# Altium schematic sheet Front_Panel.SchDoc
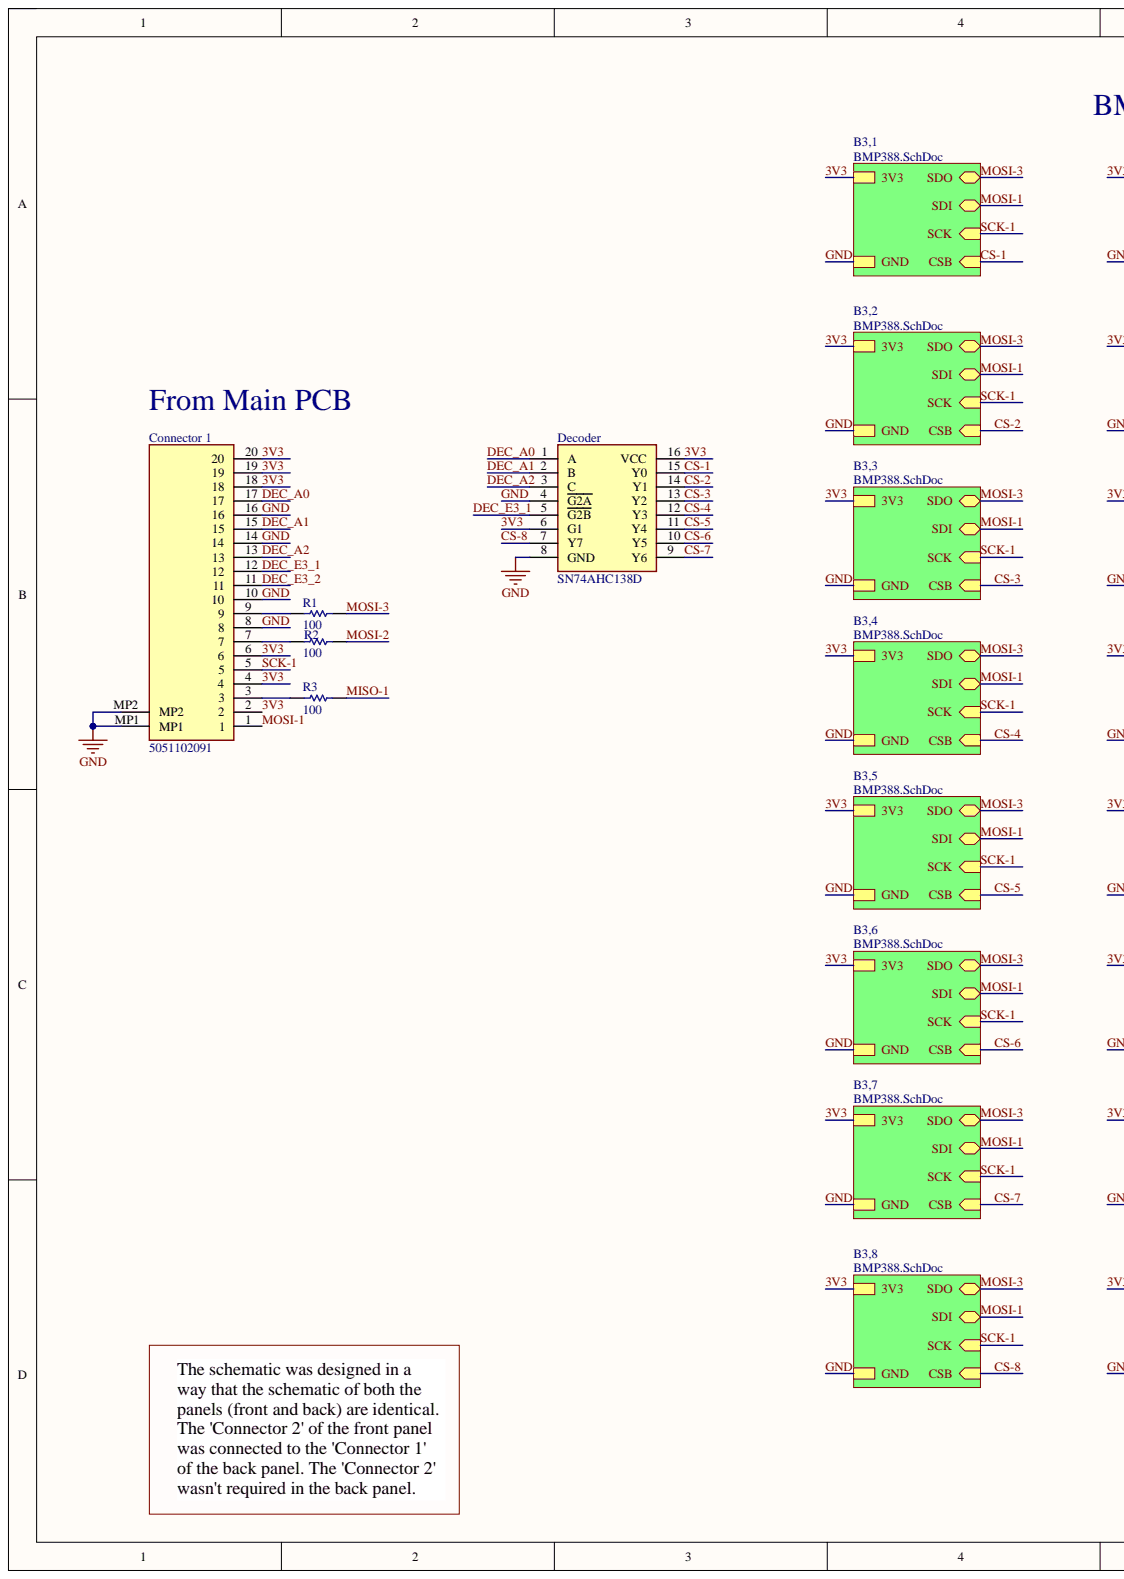
[diagram p0: Front_Panel.SchDoc, left]
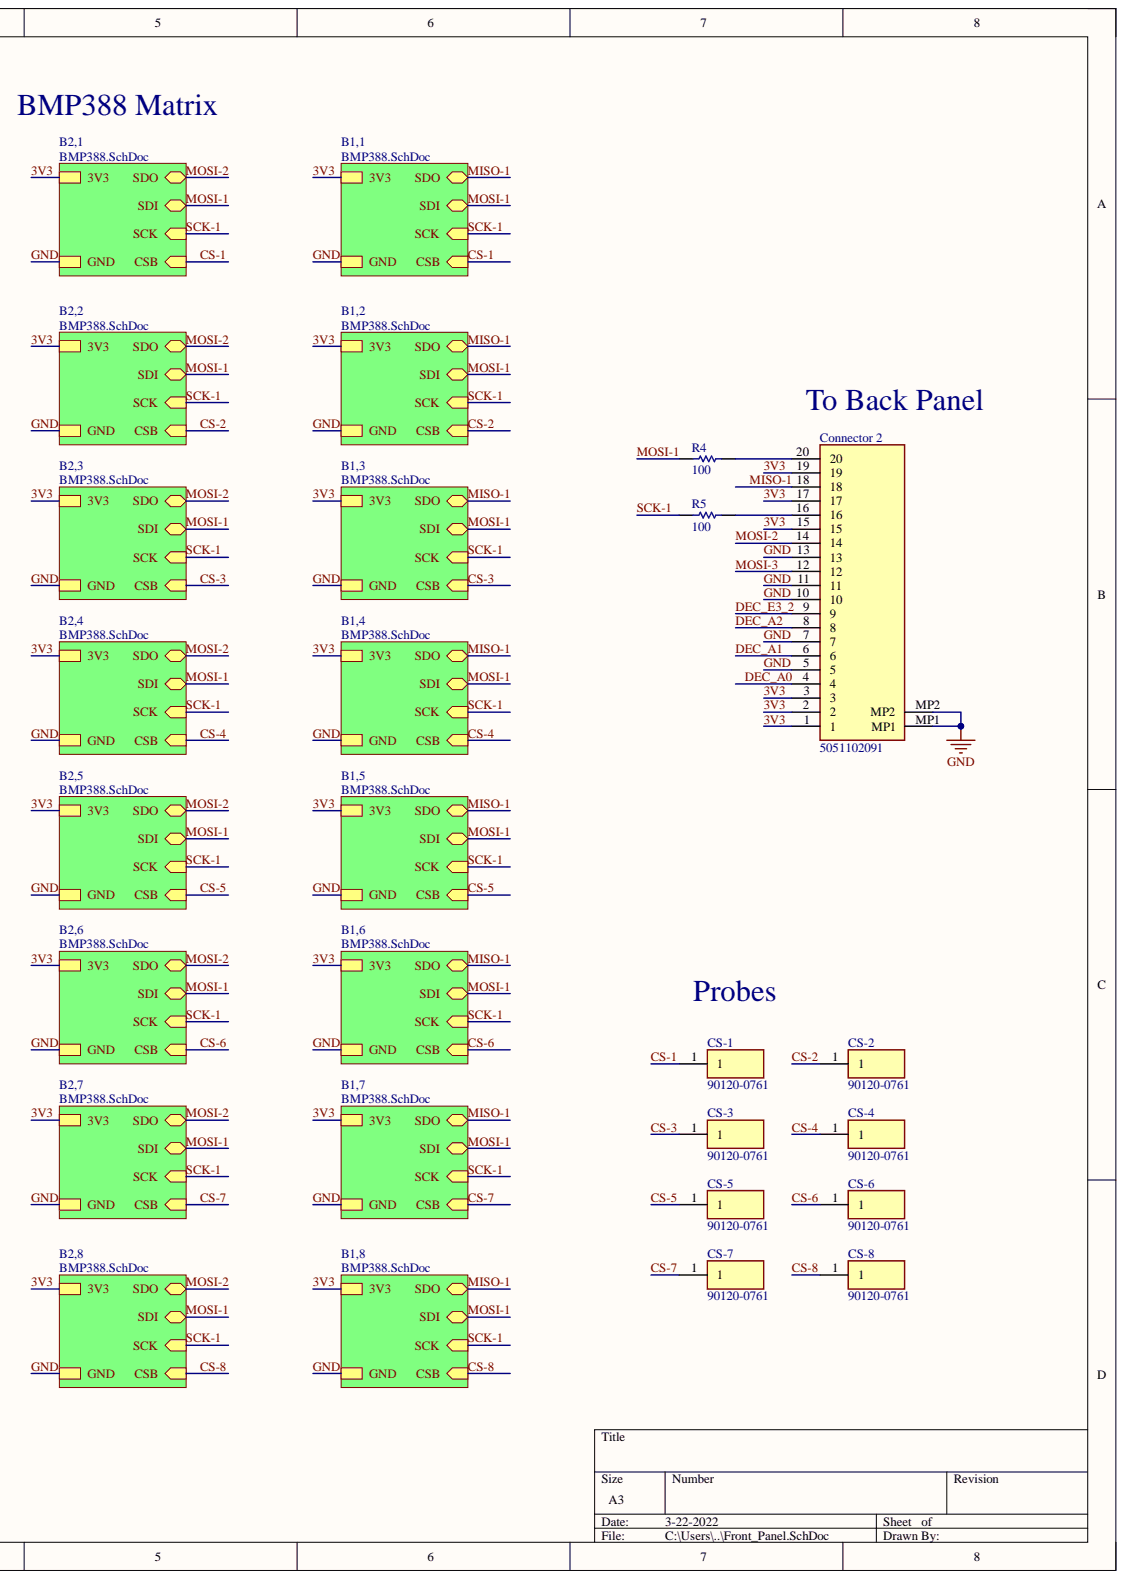
[diagram p1: Front_Panel.SchDoc, right]

## Parts
Connector_1  5051102091  [5051102091]
Connector_2  5051102091  [5051102091]
CS-1  90120-0761  [HDRV1W90P0X254_1X1_232X249X560P]
CS-2  90120-0761  [HDRV1W90P0X254_1X1_232X249X560P]
CS-3  90120-0761  [HDRV1W90P0X254_1X1_232X249X560P]
CS-4  90120-0761  [HDRV1W90P0X254_1X1_232X249X560P]
CS-5  90120-0761  [HDRV1W90P0X254_1X1_232X249X560P]
CS-6  90120-0761  [HDRV1W90P0X254_1X1_232X249X560P]
CS-7  90120-0761  [HDRV1W90P0X254_1X1_232X249X560P]
CS-8  90120-0761  [HDRV1W90P0X254_1X1_232X249X560P]
Decoder  SN74AHC138D  [SOIC127P600X175-16N]
R1  value 100  [J1-0603]  (hidden comment: Res1)
R2  value 100  [J1-0603]  (hidden comment: Res1)
R3  value 100  [J1-0603]  (hidden comment: Res1)
R4  value 100  [J1-0603]  (hidden comment: Res1)
R5  value 100  [J1-0603]  (hidden comment: Res1)

## Nets
3V3: Connector_1.2 Connector_1.4 Connector_1.6 Connector_1.18 Connector_1.19 Connector_1.20 Connector_2.1 Connector_2.2 Connector_2.3 Connector_2.15 Connector_2.17 Connector_2.19 Decoder.6(G1) Decoder.16(VCC)
CS-1: CS-1.1 Decoder.15(Y0)
CS-2: CS-2.1 Decoder.14(Y1)
CS-3: CS-3.1 Decoder.13(Y2)
CS-4: CS-4.1 Decoder.12(Y3)
CS-5: CS-5.1 Decoder.11(Y4)
CS-6: CS-6.1 Decoder.10(Y5)
CS-7: CS-7.1 Decoder.9(Y6)
CS-8: CS-8.1 Decoder.7(Y7)
DEC_A0: Connector_1.17 Connector_2.4 Decoder.1(A)
DEC_A1: Connector_1.15 Connector_2.6 Decoder.2(B)
DEC_A2: Connector_1.13 Connector_2.8 Decoder.3(C)
DEC_E3_1: Connector_1.12 Decoder.5(G2B)
DEC_E3_2: Connector_1.11 Connector_2.9
GND: Connector_1.8 Connector_1.10 Connector_1.14 Connector_1.16 Connector_1.MP1 Connector_1.MP2 Connector_2.5 Connector_2.7 Connector_2.10 Connector_2.11 Connector_2.13 Connector_2.MP1 Connector_2.MP2 Decoder.4(G2A) Decoder.8(GND)
MISO-1: Connector_2.18 R3.1
MOSI-1: Connector_1.1 R4.1
MOSI-2: Connector_2.14 R2.1
MOSI-3: Connector_2.12 R1.1
SCK-1: Connector_1.5 R5.1
NetConnector 1_3: Connector_1.3 R3.2
NetConnector 1_7: Connector_1.7 R2.2
NetConnector 1_9: Connector_1.9 R1.2
NetConnector 2_16: Connector_2.16 R5.2
NetConnector 2_20: Connector_2.20 R4.2

## Library data of the parts
Connector_1 Connector_2 = 5051102091: Connector; Manufacturer_Name: Molex; Arrow Part Number: 5051102091; Arrow Price/Stock: https://www.arrow.com/en/products/505110-2091/molex; Component Kind: Standard; Datasheet Link: https://www.molex.com/pdm_docs/sd/5051102091_sd.pdf; Height: 2.1mm; Library Name: SamacSys.SchLib; Manufacturer_Part_Number: 5051102091
CS-1-CS-8 = 90120-0761: Connector; Manufacturer_Name: Molex; Component Kind: Standard; Datasheet Link: https://www.molex.com/pdm_docs/sd/901200761_sd.pdf; Height: 5.6mm; Library Name: Test Point.SchLib; Manufacturer_Part_Number: 90120-0761; Mouser Part Number: 538-90120-0761; Mouser Price/Stock: https://www.mouser.co.uk/ProductDetail/Molex/90120-0761?qs=PvP5v7Eimsn%2F58TpDaAAow%3D%3D
Decoder = SN74AHC138D: Integrated Circuit; Manufacturer_Name: Texas Instruments; Arrow Part Number: SN74AHC138D; Arrow Price/Stock: https://www.arrow.com/en/products/sn74ahc138d/texas-instruments; Component Kind: Standard; Datasheet Link: http://www.ti.com/lit/ds/symlink/sn74ahc138.pdf; Height: 1.75mm; Manufacturer_Part_Number: SN74AHC138D; Mouser Part Number: 595-SN74AHC138D; Mouser Price/Stock: https://www.mouser.co.uk/ProductDetail/Texas-Instruments/SN74AHC138D?qs=Zu35EjizYSS98TZDPq35aQ%3D%3D
R1-R5 = Res1: Resistor; Component Kind: Standard; LatestRevisionDate: 17-Jul-2002; LatestRevisionNote: Re-released for DXP Platform.; Library Name: Miscellaneous Devices.IntLib; PackageDescription: Axial Device, Thru-Hole; 2 Leads; 0.3 in Pin Spacing; PackageReference: AXIAL-0.3; Published: 8-Jun-2000; Signal Integrity: Res; Simulation: RESISTOR; Publisher: Altium Limited
